# Altium schematic sheet ESP32C3_VOICE_LED_MCU_Sheet.SchDoc(ESP32C3_VOICE_LED_MCU_Sheet)
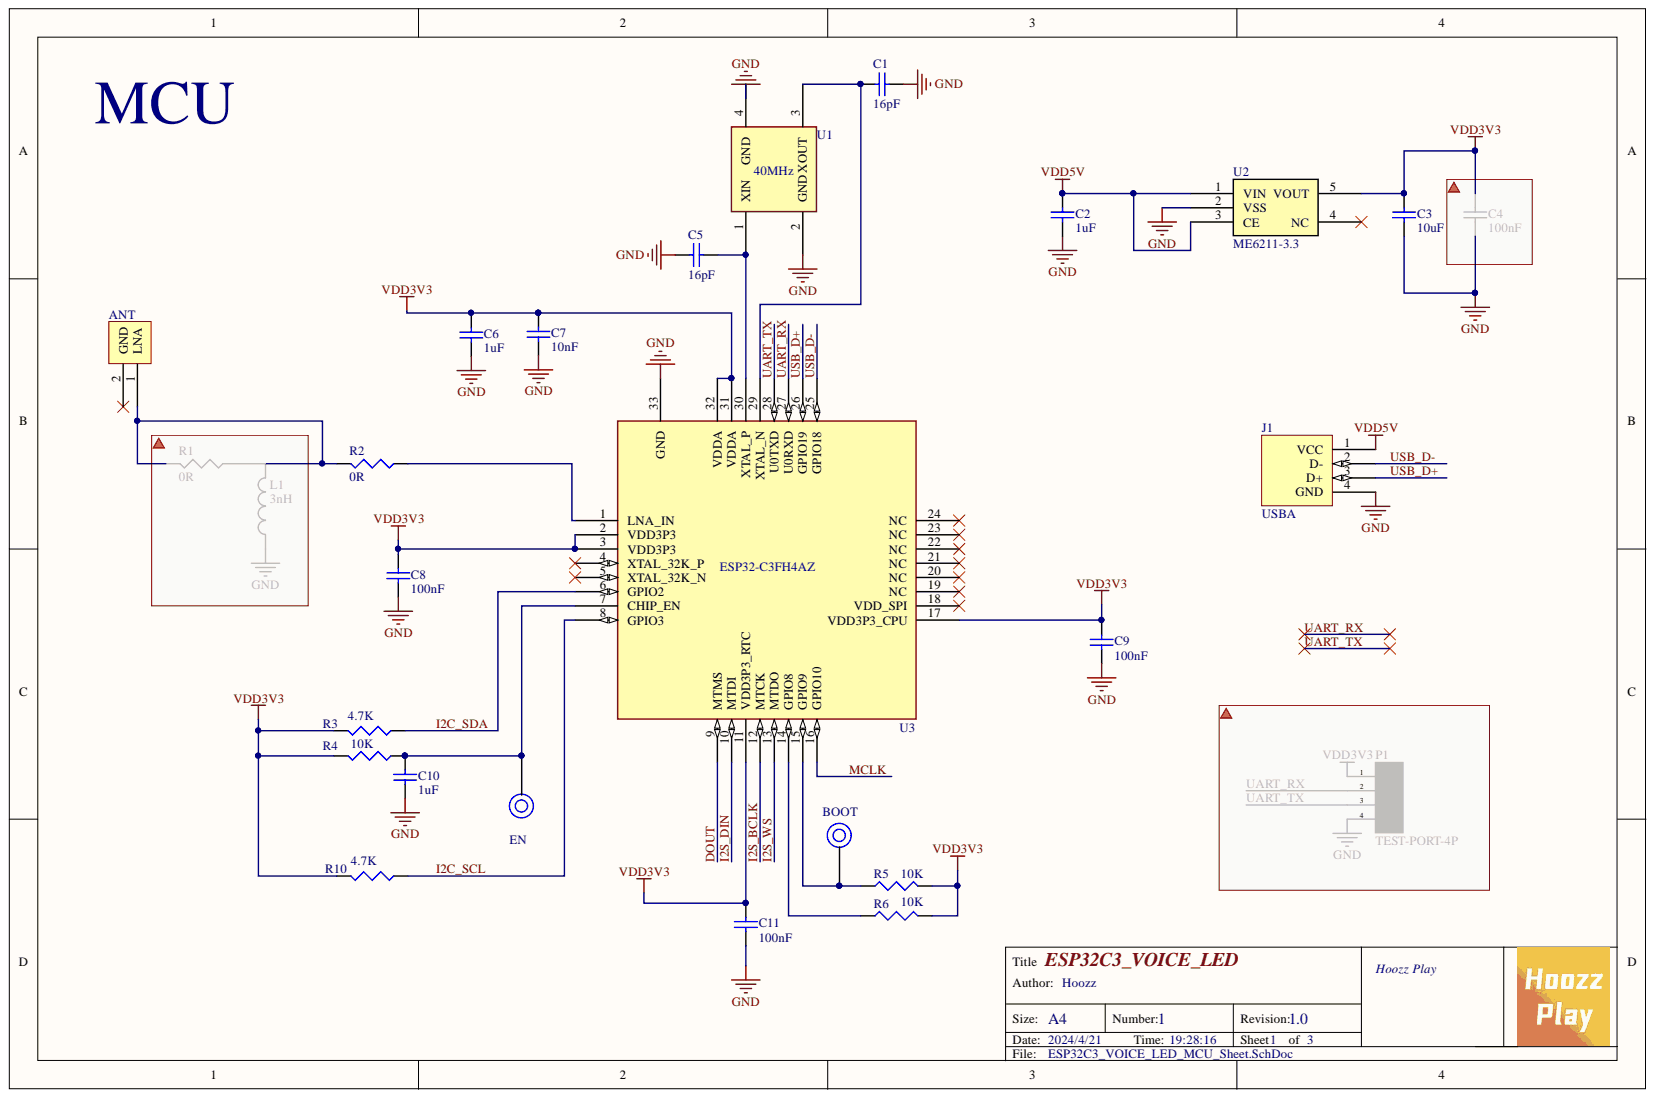
[diagram p0: ESP32C3_VOICE_LED_MCU_Sheet.SchDoc]

## Parts
ANT  ANT  [CA-C03]
BOOT  TEST-PORT  [TEST-PORT]
C1  value 16pF  [0603]  (hidden comment: Cap)
C2  value 1uF  [0603]  (hidden comment: Cap)
C3  value 10uF  [0603]  (hidden comment: Cap)
C5  value 16pF  [0603]  (hidden comment: Cap)
C6  value 1uF  [0603]  (hidden comment: Cap)
C7  value 10nF  [0603]  (hidden comment: Cap)
C8  value 100nF  [0603]  (hidden comment: Cap)
C9  value 100nF  [0603]  (hidden comment: Cap)
C10  value 1uF  [0603]  (hidden comment: Cap)
C11  value 100nF  [0603]  (hidden comment: Cap)
EN  TEST-PORT  [TEST-PORT]
J1  USBA  [USB-A-2]
R2  value 0R  [0603]  (hidden comment: Res)
R3  value 4.7K  [0603]  (hidden comment: Res)
R4  value 10K  [0603]  (hidden comment: Res)
R5  value 10K  [0603]  (hidden comment: Res)
R6  value 10K  [0603]  (hidden comment: Res)
R10  value 4.7K  [0603]  (hidden comment: Res)
U1  40MHz  [3225]
U2  ME6211-3.3  [SOT-23-5]
U3  ESP32-C3FH4AZ  [QFN32_3.7X3.7]

## Nets
GND: C1.2 C2.1 C3.1 C5.1 C6.1 C7.1 C8.1 C9.1 C10.1 C11.1 J1.4(GND) U1.2(GND) U1.4(GND) U2.2(VSS) U3.33(GND)
VDD3V3: C3.2 C6.2 C7.2 C8.2 C9.2 C11.2 R3.2 R4.2 R5.2 R6.2 R10.2 U2.5(VOUT) U3.2(VDD3P3) U3.3(VDD3P3) U3.11(VDD3P3_RTC) U3.17(VDD3P3_CPU) U3.31(VDDA) U3.32(VDDA)
VDD5V: C2.2 J1.1(VCC) U2.1(VIN) U2.3(CE)
DOUT: U3.9(MTMS)
I2C_SCL: R10.1 U3.8(GPIO3)
I2C_SDA: R3.1 U3.6(GPIO2)
I2S_BCLK: U3.12(MTCK)
I2S_DIN: U3.10(MTDI)
I2S_WS: U3.13(MTDO)
MCLK: U3.16(GPIO10)
UART_RX: U3.27(U0RXD)
UART_TX: U3.28(U0TXD)
USB_D+: J1.3(D+) U3.26(GPIO19)
USB_D-: J1.2(D-) U3.25(GPIO18)
NetANT_1: ANT.1 R2.2
NetBOOT_T: BOOT.T R5.1 U3.15(GPIO9)
NetC1_1: C1.1 U1.3(XOUT) U3.29(XTAL_N)
NetC5_2: C5.2 U1.1(XIN) U3.30(XTAL_P)
NetC10_2: C10.2 EN.T R4.1 U3.7(CHIP_EN)
NetR2_1: R2.1 U3.1(LNA_IN)
NetR6_1: R6.1 U3.14(GPIO8)

Not connected: ANT 2; U2 4(NC); U3 4(XTAL_32K_P) 5(XTAL_32K_N) 18(VDD_SPI) 19(NC) 20(NC) 21(NC) 22(NC) 23(NC) 24(NC)

## Library data of the parts
C1-C3 C5-C11 = Cap: Capacitor; Published: 8-Jun-2000; PackageReference: RAD-0.3; PackageDescription: Radial Cap, Thru-Hole; 2 Leads; 0.3 in Pin Spacing; Library Name: CORE_COMPONENT.IntLib; LatestRevisionNote: Re-released for DXP Platform.; LatestRevisionDate: 17-Jul-2002; Component Kind: Standard; Publisher: Altium Limited
J1 = USBA: USB2.0; Library Name: CORE_COMPONENT.IntLib; Component Kind: Standard
R2-R6 R10 = Res: Resistor; Published: 8-Jun-2000; PackageReference: J1-0603; PackageDescription: Chip Resistor; Library Name: CORE_COMPONENT.IntLib; LatestRevisionNote: IPC-7351 Footprint Added.; LatestRevisionDate: 29-May-2009; Component Kind: Standard; Publisher: Altium Limited
U1 = XTAL2: Library Name: CORE_COMPONENT.IntLib; Component Kind: Standard
U2 = ME6211-3.3: No Description Available; Manufacturer_Part_Number: REG102NA-2.5/250; Vendor: Texas Instruments; SourceLibrary: TI_2016-07-06; Built_By: EMA_UL_Team; Type: DEV; RefDes: RefDes; Manufacturer: Texas Instruments; Library Name: CORE_COMPONENT.IntLib; Component Kind: Standard; Copyright: Copyright (C) 2018 Accelerated Designs. All rights reserved
ANT = ANT: Library Name: CORE_COMPONENT.IntLib; Component Kind: Standard
BOOT EN = TEST-PORT: Library Name: CORE_COMPONENT.IntLib; Component Kind: Standard
U3 = ESP32-C3FH4AZ: Library Name: CORE_COMPONENT.IntLib; Component Kind: Standard
